# Altium schematic sheet Sheet1.SchDoc
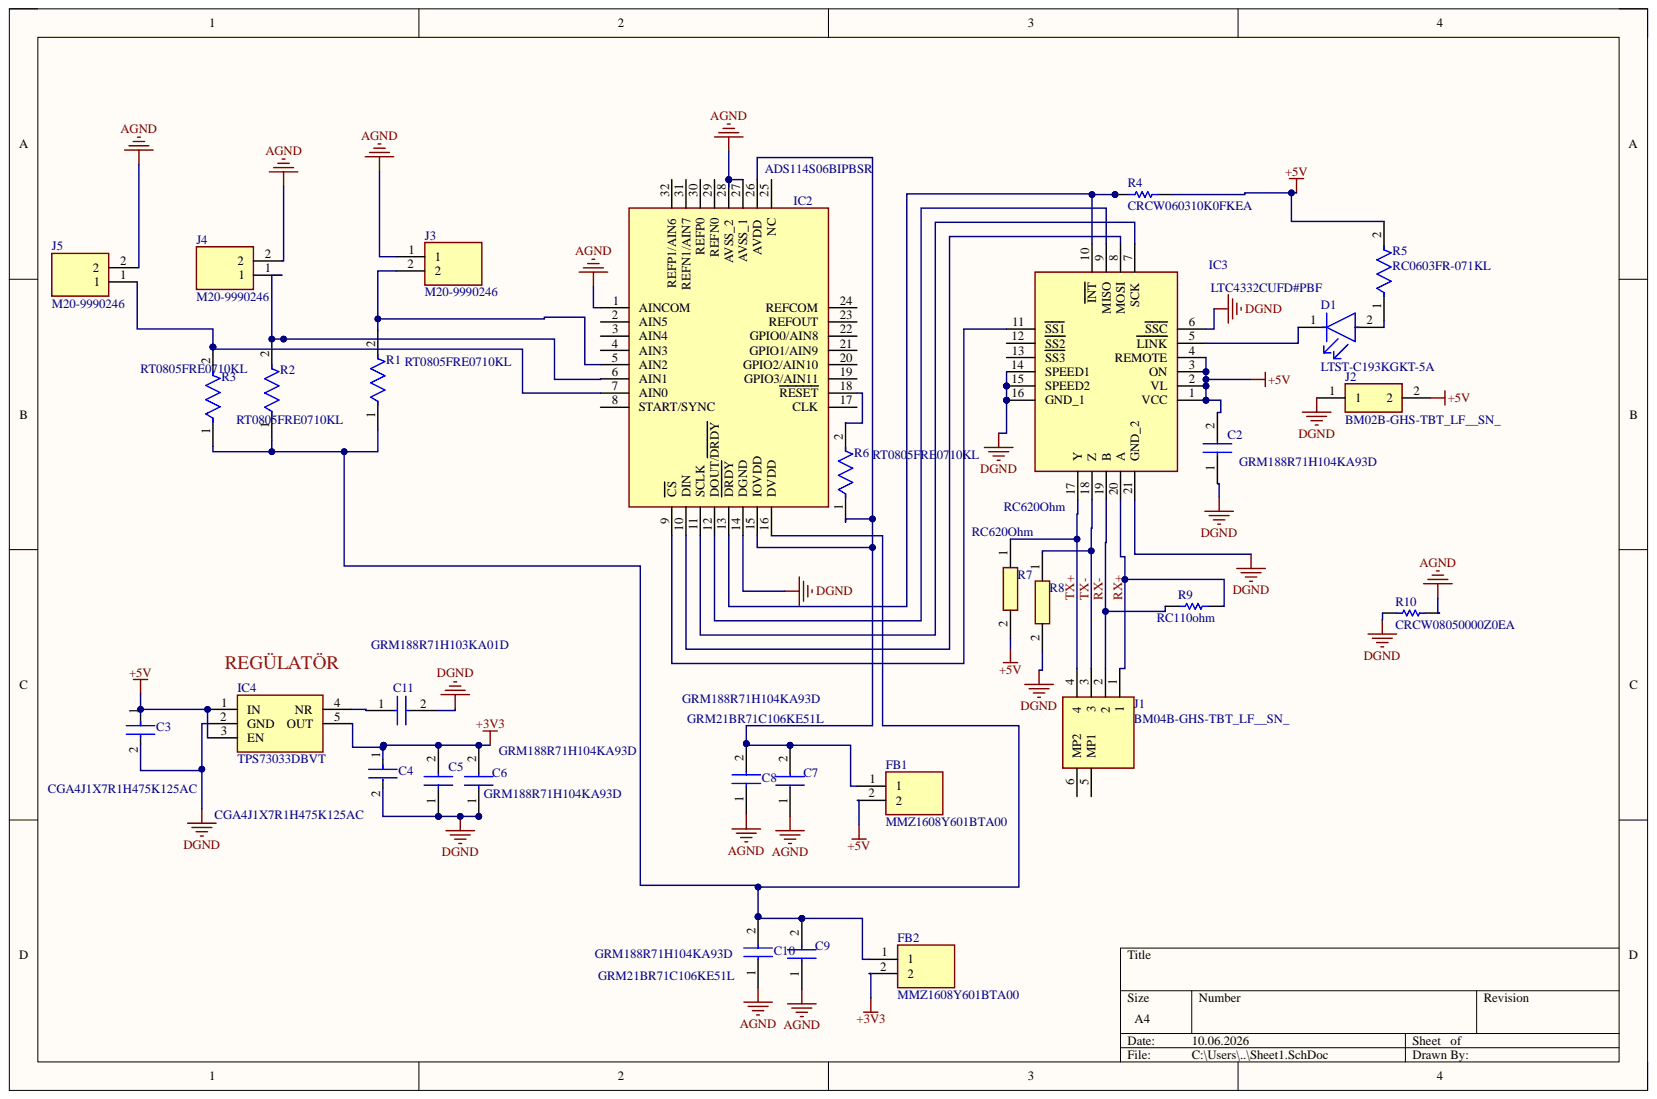
[diagram p0: Sheet1.SchDoc]

## Parts
C2  GRM188R71H104KA93D  [CAPC1608X90N]
C3  CGA4J1X7R1H475K125AC  [FP-CGA4J-IPC_C]
C4  CGA4J1X7R1H475K125AC  [FP-CGA4J-IPC_C]
C5  GRM188R71H104KA93D  [CAPC1608X90N]
C6  GRM188R71H104KA93D  [CAPC1608X90N]
C7  GRM188R71H104KA93D  [CAPC1608X90N]
C8  GRM21BR71C106KE51L  [CAPC2012X145N]
C9  GRM188R71H104KA93D  [CAPC1608X90N]
C10  GRM21BR71C106KE51L  [CAPC2012X145N]
C11  GRM188R71H103KA01D  [CAPC1608X90N]
D1  LTST-C193KGKT-5A  [FP-LTST-C193KGKT-5A-MFG]
FB1  MMZ1608Y601BTA00  [BEADC1608X95N]
FB2  MMZ1608Y601BTA00  [BEADC1608X95N]
IC2  ADS114S06BIPBSR  [QFP50P700X700X120-32N]
IC3  LTC4332CUFD#PBF  [QFN50P400X500X80-21N-D]
IC4  TPS73033DBVT  [SOT95P280X145-5N]
J1  BM04B-GHS-TBT_LF__SN_  [BM04BGHSTBTLFSN]
J2  BM02B-GHS-TBT_LF__SN_  [BM02B-GHS-TBT_1]
J3  M20-9990246  [HDRV2W66P0X254_1X2_508X254X864P]
J4  M20-9990246  [HDRV2W66P0X254_1X2_508X254X864P]
J5  M20-9990246  [HDRV2W66P0X254_1X2_508X254X864P]
R1  RT0805FRE0710KL  [FP-RT0805-MFG]
R2  RT0805FRE0710KL  [FP-RT0805-MFG]
R3  RT0805FRE0710KL  [FP-RT0805-MFG]
R4  CRCW060310K0FKEA  [RESC1609X50X30NL8T20]
R5  RC0603FR-071KL  [FP-RC0603-0_55-IPC_C]
R6  RT0805FRE0710KL  [FP-RT0805-MFG]
R7  RC620Ohm  [RESC1608X55N]
R8  RC620Ohm  [RESC1608X55N]
R9  RC110ohm  [RESC1608X55X25ML10T15]
R10  CRCW08050000Z0EA  [RESC2013X50X30LL20T20]

## Nets
+3V3: C4.1 C5.2 C6.2 FB2.2 IC4.5(OUT)
+5V: C2.2 C3.1 FB1.2 IC3.1(VCC) IC3.2(VL) IC3.3(ON) IC3.4(REMOTE) IC4.1(IN) IC4.3(EN) J2.2 R4.2 R5.2 R7.2
AGND: C7.1 C8.1 C9.1 C10.1 IC2.1(AINCOM) IC2.27(AVSS_1) IC2.28(AVSS_2) J3.1 J4.2 J5.2 R10.2
DGND: C2.1 C3.2 C4.2 C5.1 C6.1 C11.2 IC2.14(DGND) IC3.6(SSC) IC3.14(SPEED1) IC3.15(SPEED2) IC3.16(GND_1) IC3.21(GND_2) IC4.2(GND) J2.1 R8.2 R10.1
RX+: IC3.20(A) J1.1 R9.2
RX-: IC3.19(B) J1.2 R9.1
TX+: IC3.17(Y) J1.4 R7.1
TX-: IC3.18(Z) J1.3 R8.1
NetC7_2: C7.2 C8.2 FB1.1 IC2.15(IOVDD) IC2.26(AVDD) R6.1
NetC9_2: C9.2 C10.2 FB2.1 IC2.16(DVDD) R1.1 R2.1 R3.1
NetC11_1: C11.1 IC4.4(NR)
NetD1_1: D1.1 IC3.5(LINK)
NetD1_2: D1.2 R5.1
NetIC2_9: IC2.9(CS) IC3.11(SS1)
NetIC2_10: IC2.10(DIN) IC3.8(MOSI)
NetIC2_11: IC2.11(SCLK) IC3.7(SCK)
NetIC2_12: IC2.12(DOUT/DRDY) IC3.9(MISO)
NetJ3_2: IC2.5(AIN2) J3.2 R1.2
NetJ4_1: IC2.6(AIN1) J4.1 R2.2
NetJ5_1: IC2.7(AIN0) J5.1 R3.2
NetR4_1: IC2.13(DRDY) IC3.10(INT) R4.1
NetR6_2: IC2.18(RESET) R6.2

Not connected: IC2 2(AIN5) 3(AIN4) 4(AIN3) 8(START/SYNC) 17(CLK) 19(GPIO3/AIN11) 20(GPIO2/AIN10) 21(GPIO1/AIN9) 22(GPIO0/AIN8) 23(REFOUT) 24(REFCOM) 25(NC) 29(REFN0) 30(REFP0) 31(REFN1/AIN7) 32(REFP1/AIN6); IC3 12(SS2) 13(SS3); J1 5(MP1) 6(MP2)

## Library data of the parts
C2 C5-C7 C9 = GRM188R71H104KA93D: Capacitor; Manufacturer_Name: Murata Electronics; Arrow Part Number: GRM188R71H104KA93D; Arrow Price/Stock: https://www.arrow.com/en/products/grm188r71h104ka93d/murata-manufacturing.html?utm_currency=USD&region=europe; Component Kind: Standard; Datasheet Link: https://pim.murata.com/en-sg/pim/details/?partNum=GRM188R71H104KA93%23&displayChangeClass=productDetailPrint; Height: 0.9mm; Manufacturer_Part_Number: GRM188R71H104KA93D; Mouser Part Number: 81-GRM39X104K50D; Mouser Price/Stock: https://www.mouser.co.uk/ProductDetail/Murata-Electronics/GRM188R71H104KA93D?qs=f7ZljCwbPOm85Y6l%2FNGt7A%3D%3D
C3 C4 = CMP-08246-000171-1: Cap Ceramic 4.7uF 50V X7R 10% Pad SMD 0805 +125°C Automotive T/R; Component Kind: Standard; Library Name: Altium Content Vault
C8 C10 = GRM21BR71C106KE51L: Capacitor; Manufacturer_Name: Murata Electronics; Component Kind: Standard; Datasheet Link: http://www.murata.com/~/media/webrenewal/support/library/catalog/products/capacitor/mlcc/c02e.pdf; Height: 1.45mm; Manufacturer_Part_Number: GRM21BR71C106KE51L; Mouser Part Number: N/A; Mouser Price/Stock: https://www.mouser.co.uk/ProductDetail/Murata-Electronics/GRM21BR71C106KE51L?qs=eanFghet1JPWxZBJqmvAKw%3D%3D
C11 = GRM188R71H103KA01D: Capacitor; Manufacturer_Name: Murata Electronics; Arrow Part Number: GRM188R71H103KA01D; Arrow Price/Stock: https://www.arrow.com/en/products/grm188r71h103ka01d/murata-manufacturing?region=europe; Component Kind: Standard; Datasheet Link: https://search.murata.co.jp/Ceramy/image/img/A01X/G101/ENG/GRM188R71H103KA01-01.pdf; Height: 0.9mm; Manufacturer_Part_Number: GRM188R71H103KA01D; Mouser Part Number: 81-GRM39X103K50D; Mouser Price/Stock: https://www.mouser.co.uk/ProductDetail/Murata-Electronics/GRM188R71H103KA01D?qs=WWniysbtxULrL2ljbViAbw%3D%3D
D1 = CMP-2000-06622-2: LED GREEN CLEAR CHIP SMD; Dominant Wavelength: 576.5nm; Forward Current: 30mA; Forward Voltage: 2.3V; Lens Color: Colorless; Lens Style: Diffused; Luminous Intensity: 28mcd; Number of Elements: 1; Power Dissipation: 75mW; Reverse Voltage: 5V; Test Current: 5mA; Viewing Angle: 130°; Case Code (Metric): 1608; Case Code (Imperial): 0603; Case/Package: 0603; Color: Green; Component Kind: Standard; Depth: 0.8mm; Height: 0.35mm; Lead Free: Lead Free; Length: 1.6mm; Lens Transparency: Clear; Library Name: Altium Content Vault; Max Operating Temperature: 85°C; Min Operating Temperature: -55°C; Mount: Surface Mount; Number of LEDs: 1; Number of Pins: 2; Package Quantity: 3000; Packaging: Tape and Reel; Power Rating: 75mW; Radiation Hardening: No; Width: 0.8mm; Illumination Color: Green
FB1 FB2 = MMZ1608Y601BTA00: Ferrite Bead; Manufacturer_Name: TDK; Arrow Part Number: MMZ1608Y601BTA00; Arrow Price/Stock: https://www.arrow.com/en/products/mmz1608y601bta00/tdk?utm_currency=USD&region=nac; Component Kind: Standard; Datasheet Link: https://product.tdk.com/system/files/dam/doc/product/emc/emc/beads/catalog/beads_commercial_signal_mmz1608_en.pdf; Height: 0.95mm; Manufacturer_Part_Number: MMZ1608Y601BTA00; Mouser Part Number: 810-MMZ1608Y601BTA00; Mouser Price/Stock: https://www.mouser.co.uk/ProductDetail/TDK/MMZ1608Y601BTA00?qs=pLY5GE0xrmIZFSJpXFN%252BVg%3D%3D
IC2 = ADS114S06BIPBSR: Integrated Circuit; Manufacturer_Name: Texas Instruments; Arrow Part Number: ADS114S06BIPBSR; Arrow Price/Stock: https://www.arrow.com/en/products/ads114s06bipbsr/texas-instruments?region=nac; Component Kind: Standard; Datasheet Link: http://www.ti.com/lit/gpn/ads114s06b; Height: 1.2mm; Manufacturer_Part_Number: ADS114S06BIPBSR; Mouser Part Number: 595-ADS114S06BIPBSR; Mouser Price/Stock: https://www.mouser.co.uk/ProductDetail/Texas-Instruments/ADS114S06BIPBSR?qs=BZBei1rCqCCpf9Wu5SWBcA%3D%3D
IC3 = LTC4332CUFD#PBF: Integrated Circuit; Manufacturer_Name: Analog Devices; Arrow Part Number: LTC4332CUFD#PBF; Arrow Price/Stock: https://www.arrow.com/en/products/ltc4332cufdpbf/analog-devices?region=nac; Component Kind: Standard; Datasheet Link: https://www.analog.com/media/en/technical-documentation/data-sheets/LTC4332.pdf; Height: 0.8mm; Manufacturer_Part_Number: LTC4332CUFD#PBF; Mouser Part Number: 584-LTC4332CUFD#PBF; Mouser Price/Stock: https://www.mouser.co.uk/ProductDetail/Analog-Devices/LTC4332CUFDPBF?qs=PqoDHHvF64%2FLJo7LcYOzFA%3D%3D
IC4 = TPS73033DBVT: Integrated Circuit; Manufacturer_Name: Texas Instruments; Arrow Part Number: TPS73033DBVT; Arrow Price/Stock: https://www.arrow.com/en/products/tps73033dbvt/texas-instruments?utm_currency=USD&region=nac; Component Kind: Standard; Datasheet Link: https://datasheet.datasheetarchive.com/originals/distributors/Datasheets-37/DSA-731437.pdf; Height: 1.45mm; Manufacturer_Part_Number: TPS73033DBVT; Mouser Part Number: 595-TPS73033DBVT; Mouser Price/Stock: https://www.mouser.co.uk/ProductDetail/Texas-Instruments/TPS73033DBVT?qs=6zVL%252ByCp0mpT2adhnmyBgQ%3D%3D
J1 = BM04B-GHS-TBT_LF__SN_: Connector; Manufacturer_Name: JST (JAPAN SOLDERLESS TERMINALS); Manufacturer_Part_Number: BM04B-GHS-TBT(LF)(SN); Component Kind: Standard; Datasheet Link: https://g.componentsearchengine.com/ga/3Dstep; Height: 4.2mm; Mouser Part Number: 306-BM04BGHSTBTLFSNN; Mouser Price/Stock: https://www.mouser.co.uk/ProductDetail/JST-Commercial/BM04B-GHS-TBTLFSN?qs=cdbOS8ANM9DuBD4arU9XYQ%3D%3D
J2 = BM02B-GHS-TBT_LF__SN_: Connector; Manufacturer_Name: JST (JAPAN SOLDERLESS TERMINALS); Manufacturer_Part_Number: BM02B-GHS-TBT(LF)(SN); Component Kind: Standard; Datasheet Link: https://www.mouser.jp/datasheet/3/1498/1/H_S_MINIBENDKR_7_EN.PDF; Mouser Part Number: 306-BM02BGHSTBTLFSNN; Mouser Price/Stock: https://www.mouser.co.uk/ProductDetail/JST-Commercial/BM02B-GHS-TBTLFSN?qs=cdbOS8ANM9BvfZxSeyCAhA%3D%3D
J3-J5 = M20-9990246: Connector; Manufacturer_Name: Harwin; Component Kind: Standard; Datasheet Link: https://content.harwin.com/m/0df15f6e0f9f48e2/original/DRG-00479-Technical-Drawing-Datasheet-M20-999-pdf.pdf; Height: 8.64mm; Manufacturer_Part_Number: M20-9990246; Mouser Part Number: 855-M20-9990246; Mouser Price/Stock: https://www.mouser.co.uk/ProductDetail/Harwin/M20-9990246?qs=Jph8NoUxIfWjw4WmyRvzag%3D%3D
R1-R3 R6 = CMP-03412-023097-1: RES 10.0K OHM 1/8W 1% SMD 0805; Manufacturer: Yageo; Power Rating: 0.125W; Resistance: 10KΩ; Temperature Coefficient: 50 ppm/°C; Tolerance: 0.0001; Value: 10KΩ; Voltage Rating: 150V; Automotive: No; Category: Res; Component Kind: Standard; ComponentLink2Description: Datasheet; ComponentLink2URL: http://www.yageo.com/documents/recent/PYu-RT_1-to-0.01_RoHS_L_9.pdf; Composition: Thin Film; Device Class L1: Passive Components; Device Class L2: Resistors; Device Class L3: Chip SMD Resistors; Digikey Description: RES 10.0K OHM 1/8W 1% SMD 0805; Footprint Url: http://www.yageo.com/exep/pages/download/literatures/PYu-R_Mount_10.pdf; Height: 0.6mm; Lead Free: Yes; Library Name: Altium Content Vault; Manufacturer Part Number: RT0805FRE0710KL; Mouser Description: Thin Film Resistors 1/8W 10K ohm 1% 50ppm; Octopart: https://octopart.com/rt0805fre0710kl-yageo-40301150; Package: RT0805; RoHS: TRUE; Series: RT; Temperature: -55°C to +155°C; Temperature Range High: +155°C; Temperature Range Low: -55°C; Ipc Land Pattern Name: RESC200125X50
R4 = CMP-1666-00005-4: Chip Resistor, 10 KOhm, +/- 1%, 100 mW, -55 to 155 degC, 0603 (1608 Metric), RoHS, Tape and Reel; Manufacturer: Vishay Semiconductor; Voltage Rating: 75 V; Component Kind: Standard; ComponentLink1Description: Manufacturer URL; ComponentLink1URL: http://www.vishay.com/; ComponentLink2Description: Datasheet; ComponentLink2URL: https://datasheet.ciiva.com/11809/0900766b80d98afc-11809037.pdf; DatasheetVersion: 06/2012; Height: 0.45 mm; Length: 1.55 mm; Library Name: Altium Content Vault; Max Operating Temperature: 155 degC; Min Operating Temperature: -55 degC; Mounting Technology: Surface Mount; Package Quantity: 5000; PackageDescription: 2-Pin Surface Mount Device, Body 1.575 x 0.85 mm; PackageReference: 0603; Packaging: Tape and Reel; REACH SVHC: Unknown; RoHSCompliant: true
R5 = CMP-1659-00009-5: RES 1K OHM 1% 1/10W 0603; Max Power Dissipation: 100mW; Resistance: 1kR; Temperature Coefficient: 100ppm/°C; Voltage Rating: 50V; Case Code (Metric): 1608; Case Code (Imperial): 0603; Case/Package: 0603; Component Kind: Standard; Construction: Rectangular; Contact Plating: Tin; Depth: 0.8mm; Features: Moisture Resistant; Height: 0.45mm; Lead Free: Lead Free; Length: 1.6mm; Library Name: Altium Content Vault; Max Operating Temperature: 155°C; Military Standard: Not; Min Operating Temperature: -55°C; Number of Terminations: 2; Packaging: Tape and Reel; Power Rating: 100mW; Radiation Hardening: No; REACH SVHC: No SVHC; Resistance Tolerance: 1%; Resistor Type: Thick Film; RoHS Compliant: Yes; Series: RC; Size Code: 0603; Technology: THICK FILM; Termination: SMD/SMT; Tolerance: 1%
R7 R8 = RC0603FR-07620RL: Resistor; Manufacturer_Name: Yageo Group; Arrow Part Number: RC0603FR-07620RL; Arrow Price/Stock: https://www.arrow.com/en/products/rc0603fr-07620rl/yageo; Component Kind: Standard; Datasheet Link: http://www.yageo.com/documents/recent/PYu-RC0603_51_RoHS_L_v5.pdf; Height: 0.55mm; Manufacturer_Part_Number: RC0603FR-07620RL; Mouser Part Number: 603-RC0603FR-07620RL; Mouser Price/Stock: https://www.mouser.co.uk/ProductDetail/Yageo/RC0603FR-07620RL?qs=2cAdsCoAWRGiT0d%2Fv83%2FAQ%3D%3D
R9 = CMP-2000-04887-1: Manufacturer: Yageo; Part Number: RC0603FR-07110RL; Component Kind: Standard; Library Name: Altium Content Vault
R10 = CMP-2001-04896-1: Manufacturer: Vishay Dale; Component Kind: Standard; Library Name: Altium Content Vault; Part Number: CRCW08050000Z0EA
